# Altium schematic sheet POWER_BATT_REV2.SchDoc(POWER_BATT_REV2)
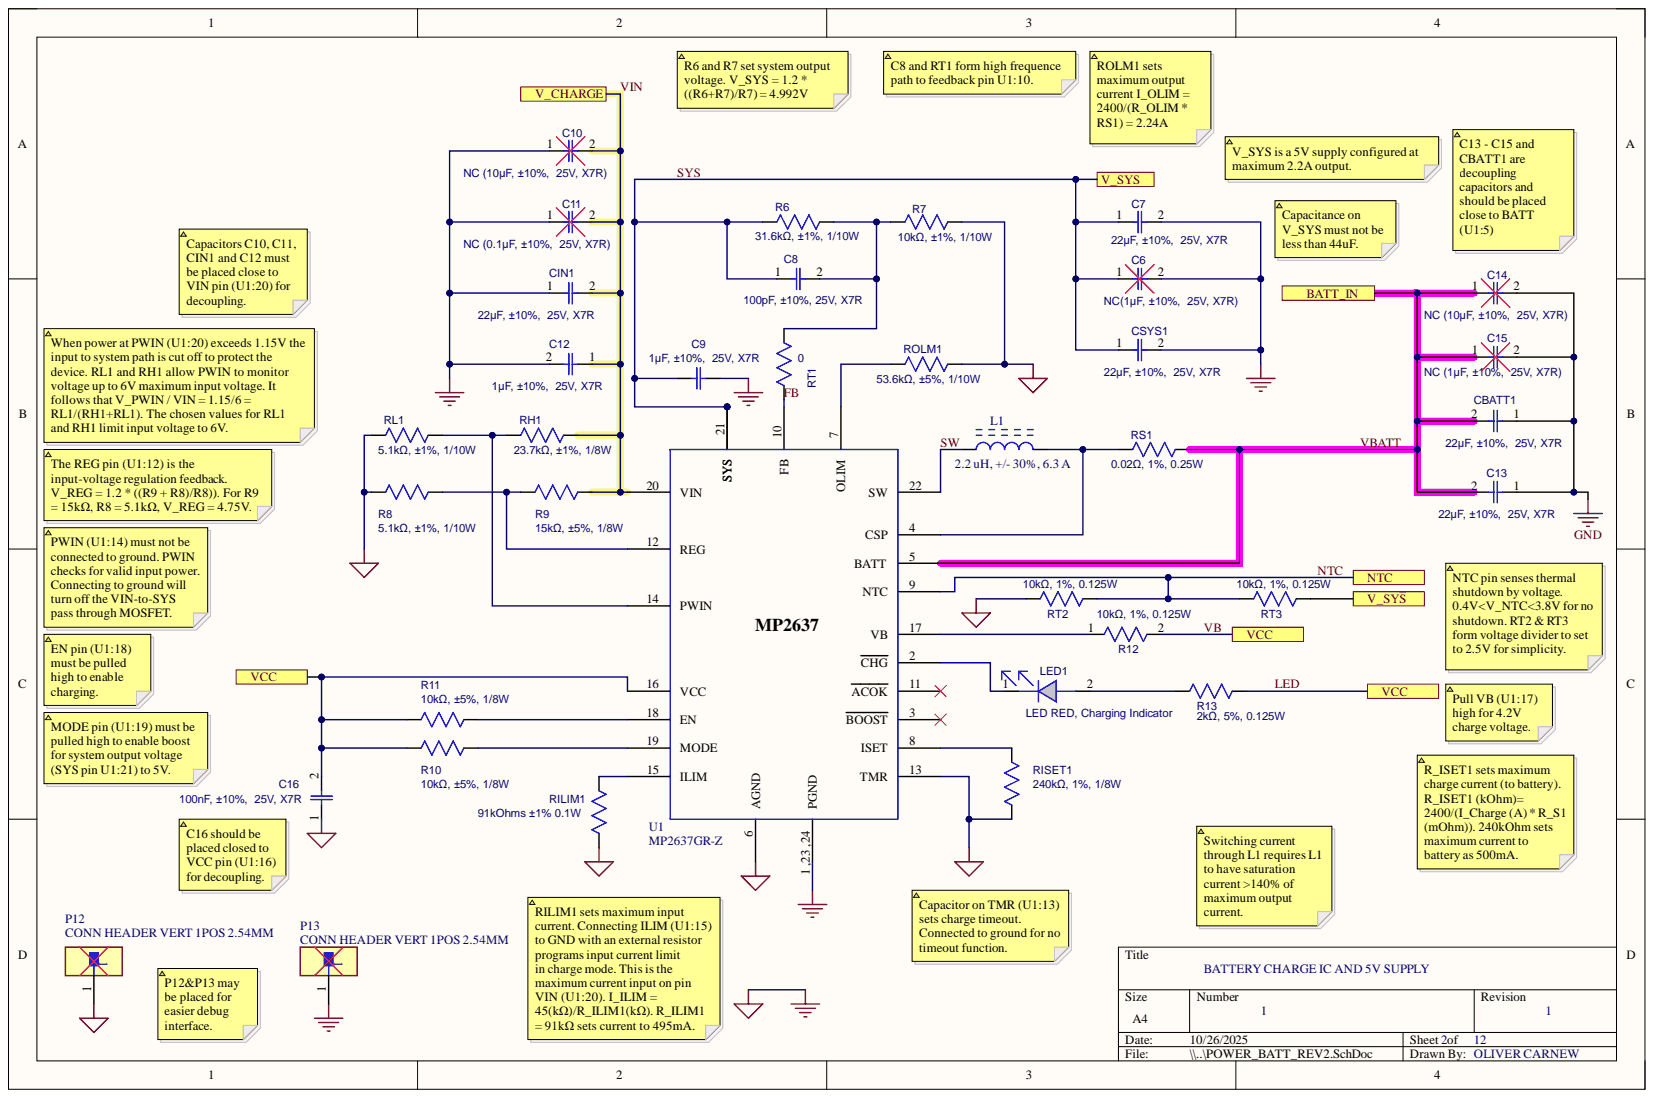
[diagram p0: POWER_BATT_REV2.SchDoc]

## Parts
*  NC
*  NC
*  NC
*?  NC  (value not drawn)
*?  NC  (value not drawn)
*?  NC  (value not drawn)
*?  NC  (value not drawn)
C6  NC(1µF, ±10%,  25V, X7R)  [CAP/0603]
C7  22µF, ±10%,  25V, X7R  [CAP/1206]
C8  100pF, ±10%, 25V, X7R  [CAP/0603]
C9  1µF, ±10%,  25V, X7R  [CAP/0603]
C10  NC (10µF, ±10%,  25V, X7R)  [CAP/0603]
C11  NC (0.1µF, ±10%,  25V, X7R)  [CAP/1206]
C12  1µF, ±10%,  25V, X7R  [CAP/0603]
C13  22µF, ±10%,  25V, X7R  [CAP/1206]
C14  NC (10µF, ±10%,  25V, X7R)  [CAP/0603]
C15  NC (1µF, ±10%,  25V, X7R)  [CAP/1206]
C16  100nF, ±10%,  25V, X7R  [CAP/0603]
CBATT1  22µF, ±10%,  25V, X7R  [CAP/1206]
CIN1  22µF, ±10%,  25V, X7R  [CAP/1206]
CSYS1  22µF, ±10%,  25V, X7R  [CAP/1206]
L1  2.2 uH, +/- 30%, 6.3 A  [BOUR-SRN8040_V]
LED1  LED RED, Charging Indicator  Spec: LED  [LED/SMD/0805]
P12  CONN HEADER VERT 1POS 2.54MM  [FP-PH1-01-UA-MFG]
P13  CONN HEADER VERT 1POS 2.54MM  [FP-PH1-01-UA-MFG]
R6  31.6kΩ, ±1%, 1/10W  [RES/0603]
R7  10kΩ, ±1%, 1/10W  [RES/0603]
R8  5.1kΩ, ±1%, 1/10W  [RES/0603]
R9  15kΩ, ±5%, 1/8W  [RES/0603]
R10  10kΩ, ±5%, 1/8W  [RES/0603]
R11  10kΩ, ±5%, 1/8W  [RES/0603]
R12  10kΩ, 1%, 0.125W  [RES/0603]
R13  2kΩ, 5%, 0.125W  [RES/0603]
RH1  23.7kΩ, ±1%, 1/8W  [RES/0603]
RILIM1  91kOhms ±1% 0.1W  [RES/0603]
RISET1  240kΩ, 1%, 1/8W  [RES/0603]
RL1  5.1kΩ, ±1%, 1/10W  [RES/0603]
ROLM1  53.6kΩ, ±5%, 1/10W  [RES/0603]
RS1  0.02Ω, 1%, 0.25W  [RES/1206]
RT1  value 0  [RES/0603]  (hidden comment: RES/0603)
RT2  10kΩ, 1%, 0.125W  [RES/0603]
RT3  10kΩ, 1%, 0.125W  [RES/0603]
U1  MP2637GR-Z  [QFN24/4X4]

## Ports
BATT_IN, NTC, V_CHARGE, V_SYS, V_SY~{S}, VCC

## Nets
GND: C6.2 C7.2 C9.1 C10.1 C11.1 C12.2 C13.1 C14.2 C15.2 C16.1 CBATT1.1 CIN1.1 CSYS1.2 P12.1 P13.1 R7.1 R8.1 RILIM1.1 RISET1.1 RL1.1 ROLM1.1 RT2.2 U1.1 ,23 ,24(PGND) U1.6(AGND) U1.13(TMR)
FB: RT1.2 U1.10(FB)
LED: C16.2 R10.2 R11.2 R12.2 R13.1 U1.16(VCC) ; port VCC
NTC: RT2.1 RT3.2 U1.9(NTC) ; port NTC
SW: L1.1 U1.22
SYS: C6.1 C7.1 C8.1 C9.2 CSYS1.1 R6.2 U1.21(SYS) U1.22 ; port V_SYS
V_SY~{S}: RT3.1 ; port V_SY~{S}
VBATT: C13.2 C14.1 C15.1 CBATT1.2 RS1.1 U1.5(BATT) ; port BATT_IN
VIN: C10.2 C11.2 C12.1 CIN1.2 R9.2 RH1.2 U1.20(VIN) ; port V_CHARGE
NetC8_2: C8.2 R6.1 R7.2 RT1.1
NetL1_2: L1.2 RS1.2 U1.4(CSP)
NetLED1_1: LED1.1 U1.2(CHG)
NetLED1_2: LED1.2 R13.2
NetR8_2: R8.2 R9.1 U1.12(REG)
NetR10_1: R10.1 U1.19(MODE)
NetR11_1: R11.1 U1.18(EN)
NetR12_1: R12.1 U1.17(VB)
NetRH1_1: RH1.1 RL1.2 U1.14(PWIN)
NetRILIM1_2: RILIM1.2 U1.15(ILIM)
NetRISET1_2: RISET1.2 U1.8(ISET)
NetROLM1_2: ROLM1.2 U1.7(OLIM)

Not connected: U1 3(BOOST) 11(ACOK)

## Library data of the parts
C6 = 07.0043: Ceramic Capacitor;16V;X7R;0603;; Manufacture: muRata; Manufacture_PN: GRM188R71C105KA12D; Manufacturer: {Manufacturer}; Manufacturer P/N: {Manufacturer P/N}; Available_Num: 5801; Database Table Name: SYS_Capacitor; Note: 1uF/16V; Out_Num: 0; Pid: 1505; Component Kind: Standard; Package: 0603; Part Number: 07.0043; Part_Name: GRM188R71C105KA12D; Spec: Ceramic Capacitor; Stock_Num: 5801; Library Name: MPSLab.DbLib
C7 C15 CSYS1 = 07.0581: Ceramic Capacitor;10V;X7R;1206; Manufacture: muRata; Manufacture_PN: GRM31CR71A226KE15L; Manufacturer: {Manufacturer}; Manufacturer P/N: {Manufacturer P/N}; Available_Num: 1278; Database Table Name: SYS_Capacitor; Note: 22uF/10V/1206; Out_Num: 0; Pid: 2003; Component Kind: Standard; Package: 1206; Part Number: 07.0581; Part_Name: GRM31CR71A226KE15L; Spec: Ceramic Capacitor; Stock_Num: 1278; Library Name: MPSLab.DbLib
C8 = 07.0138: Ceramic Capacitor;50V;C0G;0603; 100pF; Manufacture: TDK; Manufacture_PN: C1608C0G1H470J; Manufacturer: {Manufacturer}; Manufacturer P/N: {Manufacturer P/N}; Available_Num: 5335; Database Table Name: SYS_Capacitor; Note: 47pF/50V; Out_Num: 0; Pid: 1600; Component Kind: Standard; Package: 0603; Part Number: 07.0138; Part_Name: C1608C0G1H470J; Spec: Ceramic Capacitor; Stock_Num: 5335; Library Name: MPSLab.DbLib
C9 = 07.0043: Ceramic Capacitor;16V;X7R;0603;; Manufacture: muRata; Manufacture_PN: GRM188R71C105KA12D; Manufacturer: {Manufacturer}; Manufacturer P/N: {Manufacturer P/N}; Available_Num: 2297; Database Table Name: SYS_Capacitor; Out_Num: 0; Pid: 680; Component Kind: Standard; Package: 0603; Part Number: 07.0043; Part_Name: GRM188R71C105KA12D; Spec: Ceramic Capacitor; Stock_Num: 2297; Library Name: MPSLab.DbLib
C10 = 07.0772: Ceramic Capacitor;25V;X7R; Manufacture: TDK; Manufacture_PN: C1608X7R1E105K; Manufacturer: {Manufacturer}; Manufacturer P/N: {Manufacturer P/N}; Available_Num: 2930; Database Table Name: SYS_Capacitor; Note: 1uF/25V; Out_Num: 310; Pid: 2193; Component Kind: Standard; Package: 0603; Part Number: 07.0772; Part_Name: C1608X7R1E105K; Spec: Ceramic Capacitor; Stock_Num: 3240; Library Name: MPSLab.DbLib
C11 CIN1 = 07.0752: Ceramic Capacitor;25V;X5R;; Manufacture: Murata; Manufacture_PN: GRM31CR61E226KE15; Manufacturer: {Manufacturer}; Manufacturer P/N: {Manufacturer P/N}; Available_Num: 1967; Database Table Name: SYS_Capacitor; Note: 22uF/25V/1206; Out_Num: 260; Pid: 2173; Component Kind: Standard; Package: 1206; Part Number: 07.0752; Part_Name: GRM31CR61E226KE15; Spec: Ceramic Capacitor; Stock_Num: 2227; Library Name: MPSLab.DbLib
C12 = 07.0772: Ceramic Capacitor;25V;X7R; Manufacture: TDK; Manufacture_PN: C1608X7R1E105K; Manufacturer: {Manufacturer}; Manufacturer P/N: {Manufacturer P/N}; Available_Num: 0; Database Table Name: SYS_Capacitor; Note: 1uF/25V; Pid: 2193; Component Kind: Standard; Out_Num: 0; Package: 0603; Part Number: 07.0772; Part_Name: C1608X7R1E105K; Spec: Ceramic Capacitor; Stock_Num: 0; Library Name: MPSLab.DbLib
C13 = 07.0581: Ceramic Capacitor;10V;X7R;1206; 22µF, ±10%,  25V, X7R; Manufacture: muRata; Manufacture_PN: GRM31CR71A226KE15L; Manufacturer: {Manufacturer}; Manufacturer P/N: {Manufacturer P/N}; Available_Num: 1278; Database Table Name: SYS_Capacitor; Out_Num: 0; Pid: 1170; Component Kind: Standard; Package: 1206; Part Number: 07.0581; Part_Name: GRM31CR71A226KE15L; Spec: Ceramic Capacitor; Stock_Num: 1278; Library Name: MPSLab.DbLib
C14 = 07.0043: Ceramic Capacitor;16V;X7R;0603; NC (22µF, ±10%,  25V, X7R); Manufacture: muRata; Manufacture_PN: GRM188R71C105KA12D; Manufacturer: {Manufacturer}; Manufacturer P/N: {Manufacturer P/N}; Available_Num: 5801; Database Table Name: SYS_Capacitor; Note: 1uF/16V; Out_Num: 0; Pid: 1505; Component Kind: Standard; Package: 0603; Part Number: 07.0043; Part_Name: GRM188R71C105KA12D; Spec: Ceramic Capacitor; Stock_Num: 5801; Library Name: MPSLab.DbLib
C16 = 07.0053: Ceramic Capacitor;16V;X7R;0603;100nF, ±10%,  25V, X7R; Manufacture: muRata; Manufacture_PN: GRM188R71C104KA01D; Manufacturer: {Manufacturer}; Manufacturer P/N: {Manufacturer P/N}; Available_Num: 848; Database Table Name: SYS_Capacitor; Note: 100nF/16V; Out_Num: 1670; Pid: 1515; Component Kind: Standard; Package: 0603; Part Number: 07.0053; Part_Name: GRM188R71C104KA01D; Spec: Ceramic Capacitor; Stock_Num: 2518; Library Name: MPSLab.DbLib
CBATT1 = 07.0581: Ceramic Capacitor;10V;X7R;1206; Manufacture: muRata; Manufacture_PN: GRM31CR71A226KE15L; Manufacturer: {Manufacturer}; Manufacturer P/N: {Manufacturer P/N}; Available_Num: 1278; Database Table Name: SYS_Capacitor; Out_Num: 0; Pid: 1170; Component Kind: Standard; Package: 1206; Part Number: 07.0581; Part_Name: GRM31CR71A226KE15L; Spec: Ceramic Capacitor; Stock_Num: 1278; Library Name: MPSLab.DbLib
L1 = CMP-2000-07144-1: Semi-Shielded Power Inductor, 2.2 uH, +/- 30%, 6.3 A, -40 to 125 degC, 2-Pin SMD, RoHS, Tape and Reel SRN8040-2R2Y; Manufacturer: Bourns; Brand/Series: SRN8040Series; Current, Rating: 6.3A; Inductance: 2.2μH; Component Kind: Standard; Datasheet URL: http://www.bourns.com/docs/Product-Datasheets/SRN8040.pdf; Datasheet Version: 05/2015; Library Name: Altium Content Vault; Manufacturer URL: http://www.bourns.com/; Mounting Technology: SurfaceMount; Package Description: 2-Pin Surface Mounted Device, Body 8 x 8 mm; Package Reference: SRN8040; Termination: SMD; Tolerance: ±30%; Type: Power
LED1 = 19.0003: LED;红光; LED/SMD/0805; Manufacture: BRIGHT LED; Manufacture_PN: BL-HUF35A-TRB; Manufacturer: {Manufacturer}; Manufacturer P/N: {Manufacturer P/N}; Available_Num: 1960; Database Table Name: SYS_LED; Out_Num: 0; Pid: 37; Value: BL-HUF35A-TRB; Component Kind: Standard; Package: 0805; Part Number: 19.0003; Part_Name: BL-HUF35A-TRB; Stock_Num: 1960; Library Name: MPSLab.DbLib
P12 P13 = CMP-42765-000003-1: CONN HEADER VERT 1POS 2.54MM PH1-01-UA; Number of Positions: 1; Voltage Rating (AC): 250V; Component Kind: Standard; Connector Type: Header; Contact Finish - Mating: GOLD; Contact Material: Brass; Contact Shape: Square; Contact Type: Male Pin; Fastening Type: Pull; Flammability Rating: UL94 V-0; Insulation Color: Black; Insulation Material: PBT; Lead Free: Lead Free; Library Name: Altium Content Vault; Max Operating Temperature: 105°C; Min Operating Temperature: -40°C; Mount: Through Hole; Number of Rows: 1; Packaging: Bulk; Series: PH1; Termination: Solder
R6 = 06.0160: Film Resistor;1%;; Manufacture: Yageo; Manufacture_PN: RC0603FR-0731K6L; Manufacturer P/N: 9C06031A1203FKHFT; Available_Num: 4254; Database Table Name: SYS_Resistor; Note: 31.6k/1%; Out_Num: 0; Pid: 2840; Component Kind: Standard; Distributor: Digikey; Distributor P/N: 311-120KHCT-ND; Manufacturer: Yageo; Package: 0603; Part Number: 06.0160; Part_Name: RC0603FR-0731K6L; Spec: Film Resistor; Stock_Num: 4254; Library Name: MPSLab.DbLib
R7 = 06.0112: Film Resistor;1%;; Manufacture: Yageo; Manufacture_PN: RC0603FR-0710KL; Manufacturer P/N: 9C06031A1203FKHFT; Available_Num: 7415; Database Table Name: SYS_Resistor; Note: 10k/1%; Out_Num: 2310; Pid: 2792; Component Kind: Standard; Distributor: Digikey; Distributor P/N: 311-120KHCT-ND; Manufacturer: Yageo; Package: 0603; Part Number: 06.0112; Part_Name: RC0603FR-0710KL; Spec: Film Resistor; Stock_Num: 9725; Library Name: MPSLab.DbLib
R8 RL1 = 06.0691: Film Resistor;1%;; Manufacture: Yageo; Manufacture_PN: RC0603FR-075K1L; Manufacturer P/N: 9C06031A1203FKHFT; Available_Num: 5749; Database Table Name: SYS_Resistor; Note: 5.1k/1%; Out_Num: 0; Pid: 3353; Component Kind: Standard; Distributor: Digikey; Distributor P/N: 311-120KHCT-ND; Manufacturer: Yageo; Package: 0603; Part Number: 06.0691; Part_Name: RC0603FR-075K1L; Spec: Film Resistor; Stock_Num: 5749; Library Name: MPSLab.DbLib
R9 = 06.0129: Film Resistor;1%;; Manufacture: Yageo; Manufacture_PN: RC0603FR-0715KL; Manufacturer P/N: 9C06031A1203FKHFT; Available_Num: 3939; Database Table Name: SYS_Resistor; Note: 15k/1%; Out_Num: 160; Pid: 2809; Component Kind: Standard; Distributor: Digikey; Distributor P/N: 311-120KHCT-ND; Manufacturer: Yageo; Package: 0603; Part Number: 06.0129; Part_Name: RC0603FR-0715KL; Spec: Film Resistor; Stock_Num: 4099; Library Name: MPSLab.DbLib
R10 = 06.0284: Film Resistor;5%;; Manufacture: Yageo; Manufacture_PN: RC0603JR-0710K; Manufacturer P/N: 9C06031A1203FKHFT; Available_Num: 6218; Database Table Name: SYS_Resistor; Note: 10k; Out_Num: 0; Pid: 2947; Component Kind: Standard; Distributor: Digikey; Distributor P/N: 311-120KHCT-ND; Manufacturer: Yageo; Package: 0603; Part Number: 06.0284; Part_Name: RC0603JR-0710K; Spec: Film Resistor; Stock_Num: 6218; Library Name: MPSLab.DbLib
R11 = 06.0284: Manufacture: Yageo; Manufacture_PN: RC0603JR-0710K; Manufacturer P/N: 9C06031A1203FKHFT; Available_Num: 6218; Database Table Name: SYS_Resistor; Note: 10k; Out_Num: 0; Pid: 2947; Spec: Film Resistor; Component Kind: Standard; Description: 10kΩ, ±5%, 1/8W; Distributor: Digikey; Distributor P/N: 311-120KHCT-ND; Manufacturer: Yageo; Package: 0603; Part Number: 06.0284; Part_Name: RC0603JR-0710K; Stock_Num: 6218; Library Name: MPSLab.DbLib
R12 = 06.0284: Film Resistor;5%; 10kΩ, 1%, 0.125W; 0603; Manufacture: Yageo; Manufacture_PN: RC0603JR-0710K; Manufacturer P/N: 9C06031A1203FKHFT; Available_Num: 6218; Database Table Name: SYS_Resistor; Note: 10k; Out_Num: 0; Pid: 2947; Component Kind: Standard; Distributor: Digikey; Distributor P/N: 311-120KHCT-ND; Manufacturer: Yageo; Package: 0603; Part Number: 06.0284; Part_Name: RC0603JR-0710K; Spec: Film Resistor; Stock_Num: 6218; Library Name: MPSLab.DbLib
R13 = 06.0015: Film Resistor;5%;1/10W; 5kΩ, 5%, 0.125W 0603; Manufacture: LIZ; Manufacture_PN: CR0603JA0202G; Manufacturer: Yageo; Manufacturer P/N: 9C06031A1203FKHFT; Available_Num: 3523; Database Table Name: SYS_Resistor; Note: 2K; Out_Num: 0; Pid: 2695; Component Kind: Standard; Distributor: Digikey; Distributor P/N: 311-120KHCT-ND; Package: 0603; Part Number: 06.0015; Part_Name: CR0603JA0202G; Spec: Film Resistor; Stock_Num: 3523; Library Name: MPSLab.DbLib
RH1 = 06.0148: Film Resistor;1%; Manufacture: Yageo; Manufacture_PN: RC0603FR-0723K7L; Manufacturer P/N: 9C06031A1203FKHFT; Available_Num: 7686; Database Table Name: SYS_Resistor; Note: 23.7k/1%; Out_Num: 0; Pid: 2828; Component Kind: Standard; Distributor: Digikey; Distributor P/N: 311-120KHCT-ND; Manufacturer: Yageo; Package: 0603; Part Number: 06.0148; Part_Name: RC0603FR-0723K7L; Spec: Film Resistor; Stock_Num: 7686; Library Name: MPSLab.DbLib
RILIM1 = 06.0129: 91 kOhms ±1% 0.1W, 1/10W Chip Resistor 0603 (1608 Metric) Anti-Sulfur Thin FilmMCT06030C9102FP500; Manufacture: Yageo; Manufacture_PN: RC0603FR-0715KL; Manufacturer P/N: 9C06031A1203FKHFT; Available_Num: 1229; Database Table Name: SYS_Resistor; Note: 15k/1%; Out_Num: 0; Pid: 2809; Spec: Film Resistor; Value: 15K 1%; Component Kind: Standard; Distributor: Digikey; Distributor P/N: 311-120KHCT-ND; Manufacturer: Yageo; Package: 0603; Part Number: 06.0129; Part_Name: RC0603FR-0715KL; Stock_Num: 1229; Library Name: MPSLab.DbLib
RISET1 = 06.0177: Film Resistor;1%; 240kΩ, 1%, 1/8W; Manufacture: Yageo; Manufacture_PN: RC0603FR-0747K5L; Manufacturer P/N: 9C06031A1203FKHFT; Available_Num: 7700; Database Table Name: SYS_Resistor; Note: 47.5k/1%; Out_Num: 0; Pid: 2857; Component Kind: Standard; Distributor: Digikey; Distributor P/N: 311-120KHCT-ND; Manufacturer: Yageo; Package: 0603; Part Number: 06.0177; Part_Name: RC0603FR-0747K5L; Spec: Film Resistor; Stock_Num: 7700; Library Name: MPSLab.DbLib
ROLM1 = 06.0182: Film Resistor;1%; Manufacture: Yageo; Manufacture_PN: RC0603FR-0753K6L; Manufacturer P/N: 9C06031A1203FKHFT; Available_Num: 9040; Database Table Name: SYS_Resistor; Note: 53.6k/1%; Out_Num: 0; Pid: 2862; Component Kind: Standard; Distributor: Digikey; Distributor P/N: 311-120KHCT-ND; Manufacturer: Yageo; Package: 0603; Part Number: 06.0182; Part_Name: RC0603FR-0753K6L; Spec: Film Resistor; Stock_Num: 9040; Library Name: MPSLab.DbLib
RS1 = 06.0564: Film Resistor;1%; RES 0.02 OHM 1% 1/4W 1206; WSL1206R0200FEA; Manufacture: Vishay; Manufacture_PN: WSL1206-18; Manufacturer: Yageo; Manufacturer P/N: 9C06031A1203FKHFT; Available_Num: 291; Database Table Name: SYS_Resistor; Note: 20m/1%/1206; Out_Num: 0; Pid: 3227; Component Kind: Standard; Distributor: Digikey; Distributor P/N: 311-120KHCT-ND; Package: 1206; Part Number: 06.0564; Part_Name: WSL1206-18; Spec: Film Resistor; Stock_Num: 291; Library Name: MPSLab.DbLib
RT1 = 06.0039: Film Resistor;5%;; Manufacture: Yageo; Manufacture_PN: RC0603JR-070RL; Manufacturer P/N: 9C06031A1203FKHFT; Available_Num: 14667; Database Table Name: SYS_Resistor; Out_Num: 4670; Pid: 2719; Component Kind: Standard; Distributor: Digikey; Distributor P/N: 311-120KHCT-ND; Manufacturer: Yageo; Note: 0; Package: 0603; Part Number: 06.0039; Part_Name: RC0603JR-070RL; Spec: Film Resistor; Stock_Num: 19337; Library Name: MPSLab.DbLib
RT2 = 06.0112: Film Resistor;1%; 10kΩ, 1%, 0.125W; 0603; Manufacture: Yageo; Manufacture_PN: RC0603FR-0710KL; Manufacturer P/N: 9C06031A1203FKHFT; Available_Num: 16838; Database Table Name: SYS_Resistor; Note: 10k/1%; Out_Num: 2110; Pid: 2792; Component Kind: Standard; Distributor: Digikey; Distributor P/N: 311-120KHCT-ND; Manufacturer: Yageo; Package: 0603; Part Number: 06.0112; Part_Name: RC0603FR-0710KL; Spec: Film Resistor; Stock_Num: 18948; Library Name: MPSLab.DbLib
RT3 = 06.0112: Film Resistor;1%; 10kΩ, 1%, 0.125W;0603; Manufacture: Yageo; Manufacture_PN: RC0603FR-0710KL; Manufacturer P/N: 9C06031A1203FKHFT; Available_Num: 16838; Database Table Name: SYS_Resistor; Note: 10k/1%; Out_Num: 2110; Pid: 2792; Component Kind: Standard; Distributor: Digikey; Distributor P/N: 311-120KHCT-ND; Manufacturer: Yageo; Package: 0603; Part Number: 06.0112; Part_Name: RC0603FR-0710KL; Spec: Film Resistor; Stock_Num: 18948; Library Name: MPSLab.DbLib
U1 = MP2637: Component Kind: Standard; Library Name: MPS_IC.SchLib
* * * *? *? *? *? = NC: Component Kind: Standard; Library Name: DANCE_LIB_REV2.SchLib
